# Altium schematic sheet CPLD-V MAIN.SchDoc
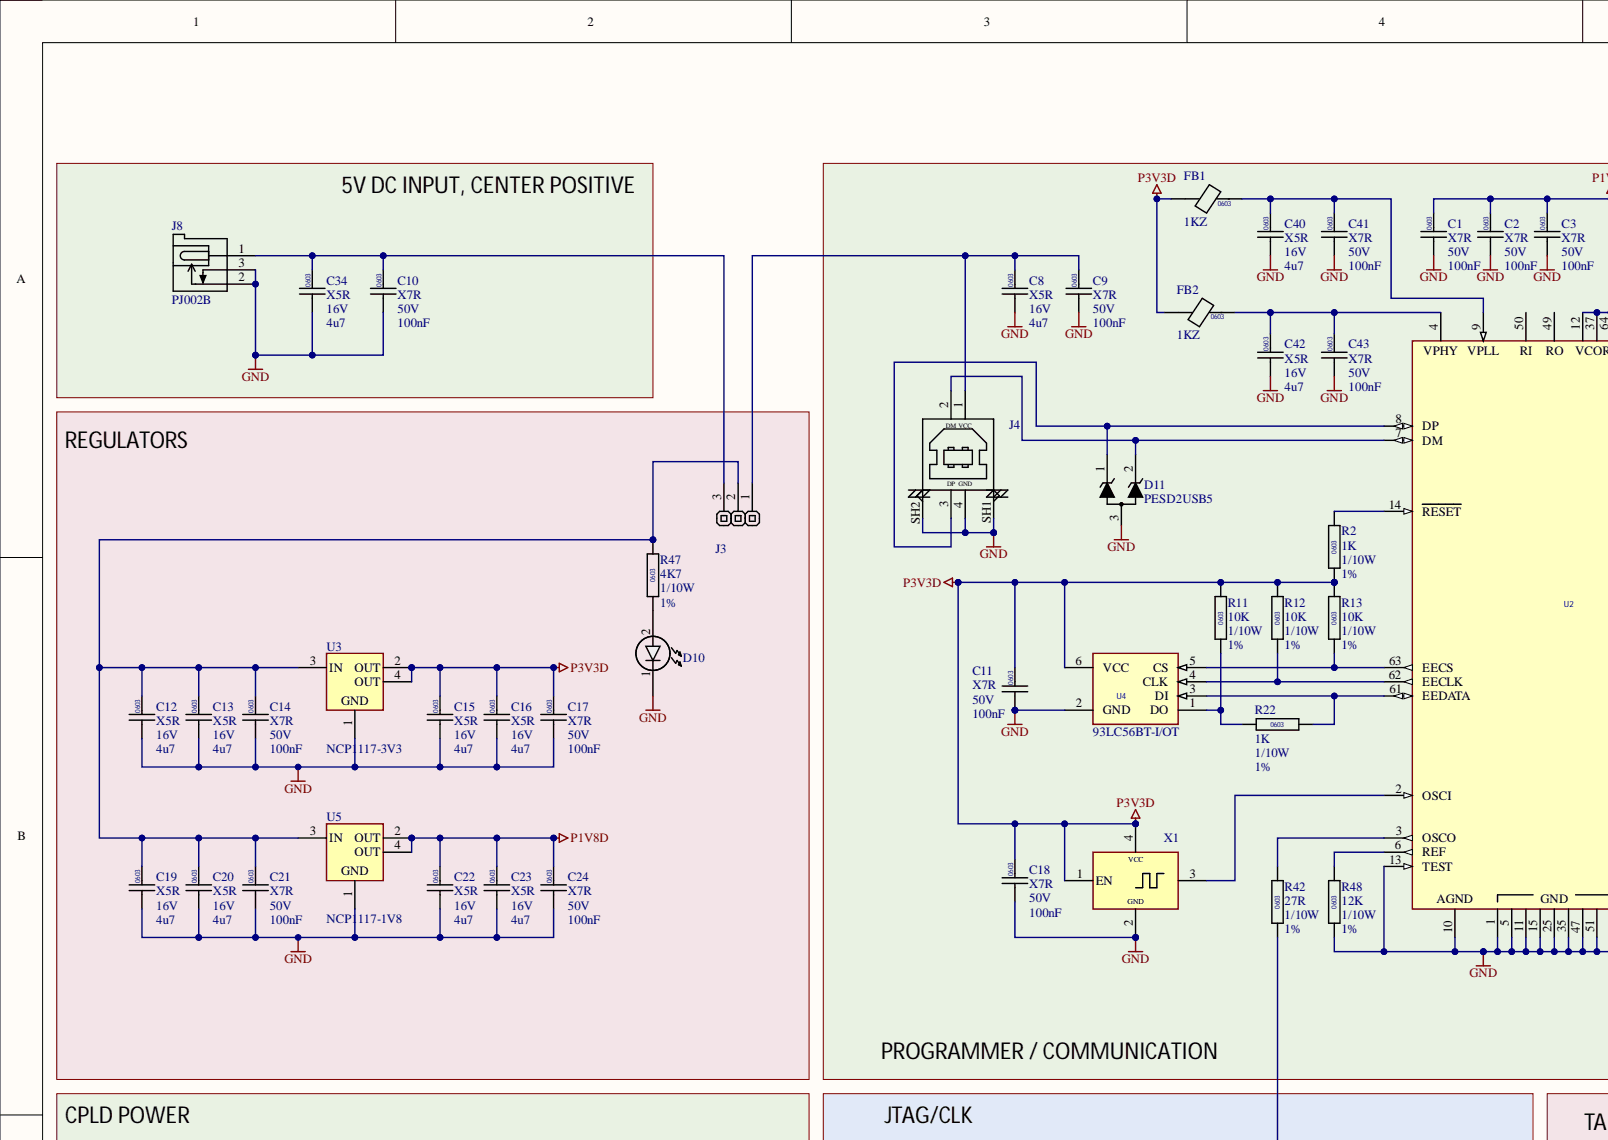
[diagram p0: CPLD-V MAIN.SchDoc, top left]
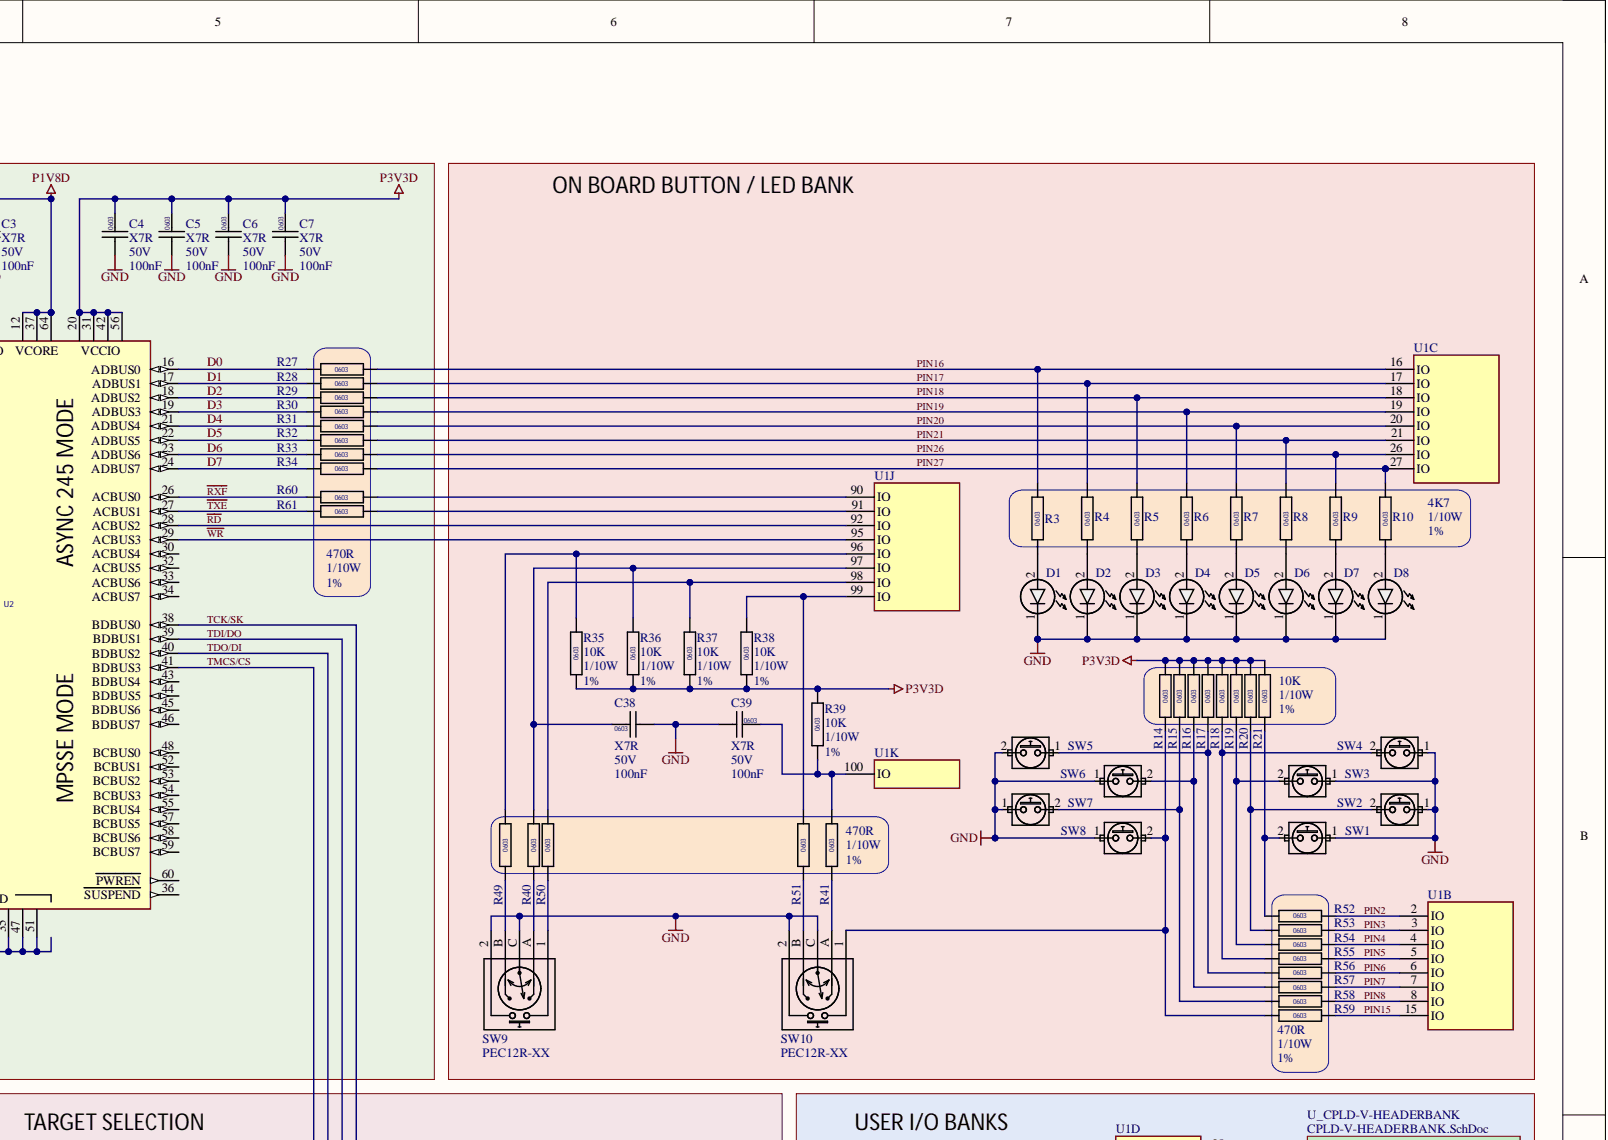
[diagram p1: CPLD-V MAIN.SchDoc, top right]
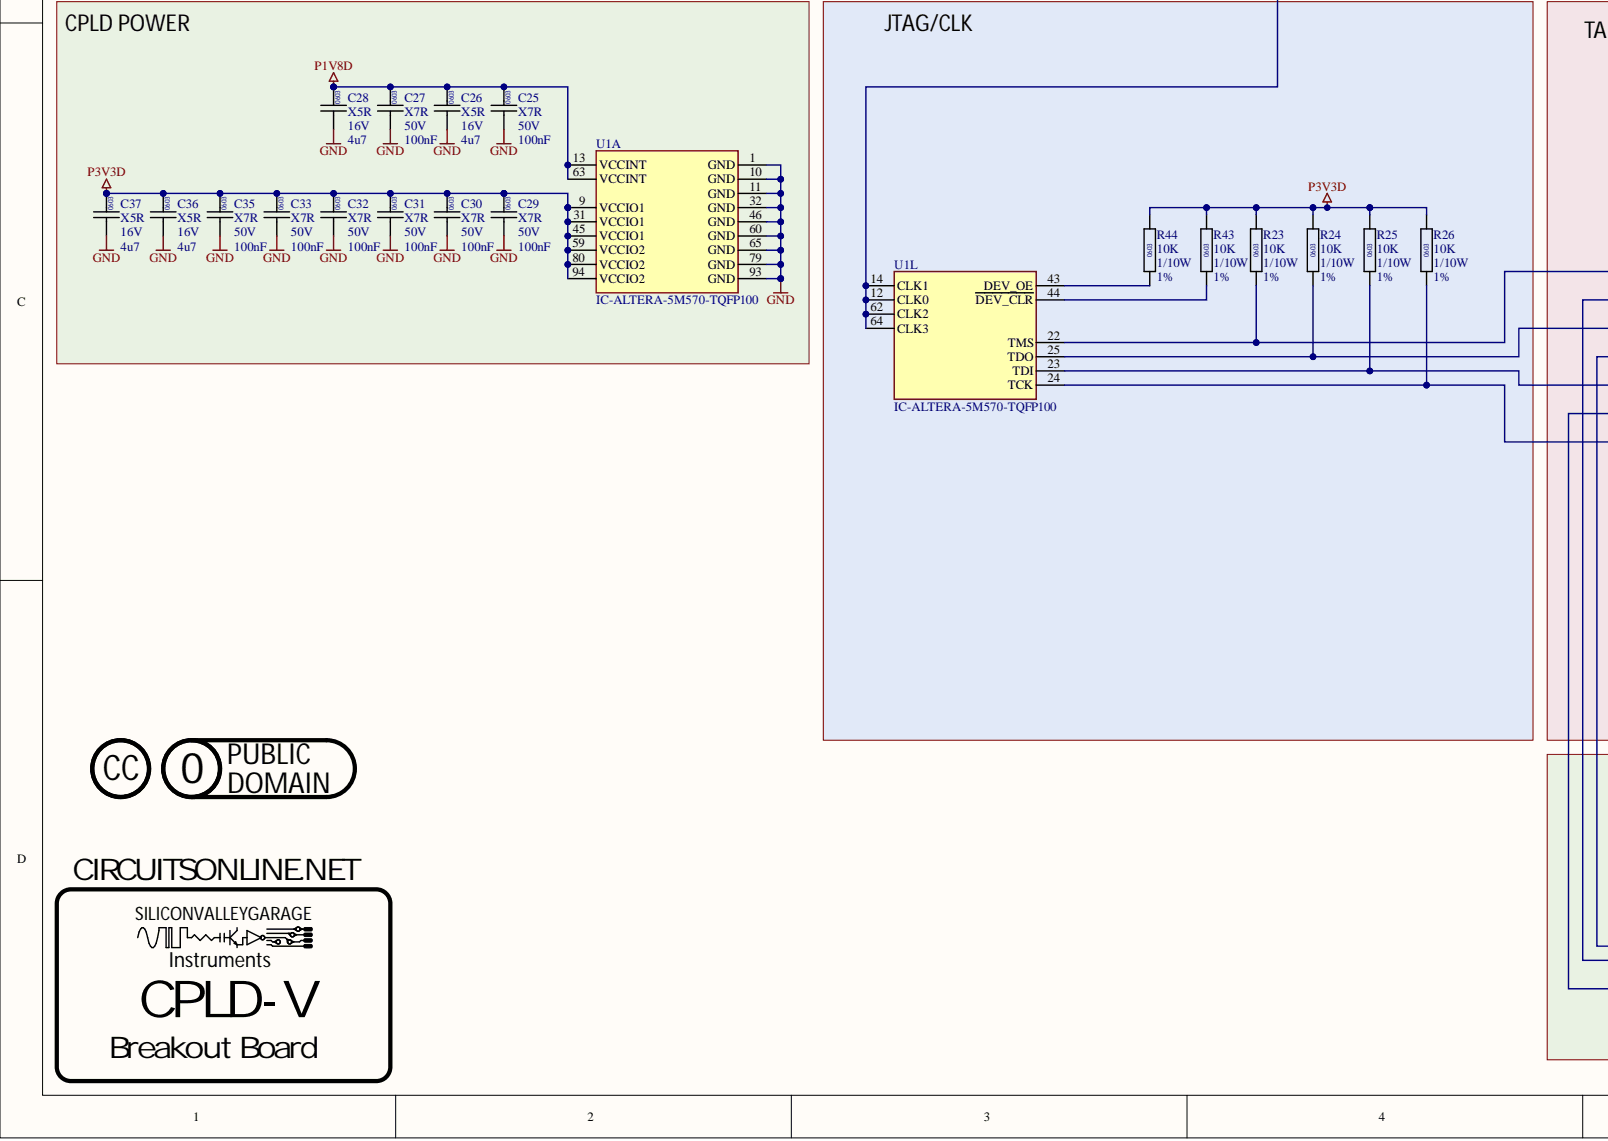
[diagram p2: CPLD-V MAIN.SchDoc, bottom left]
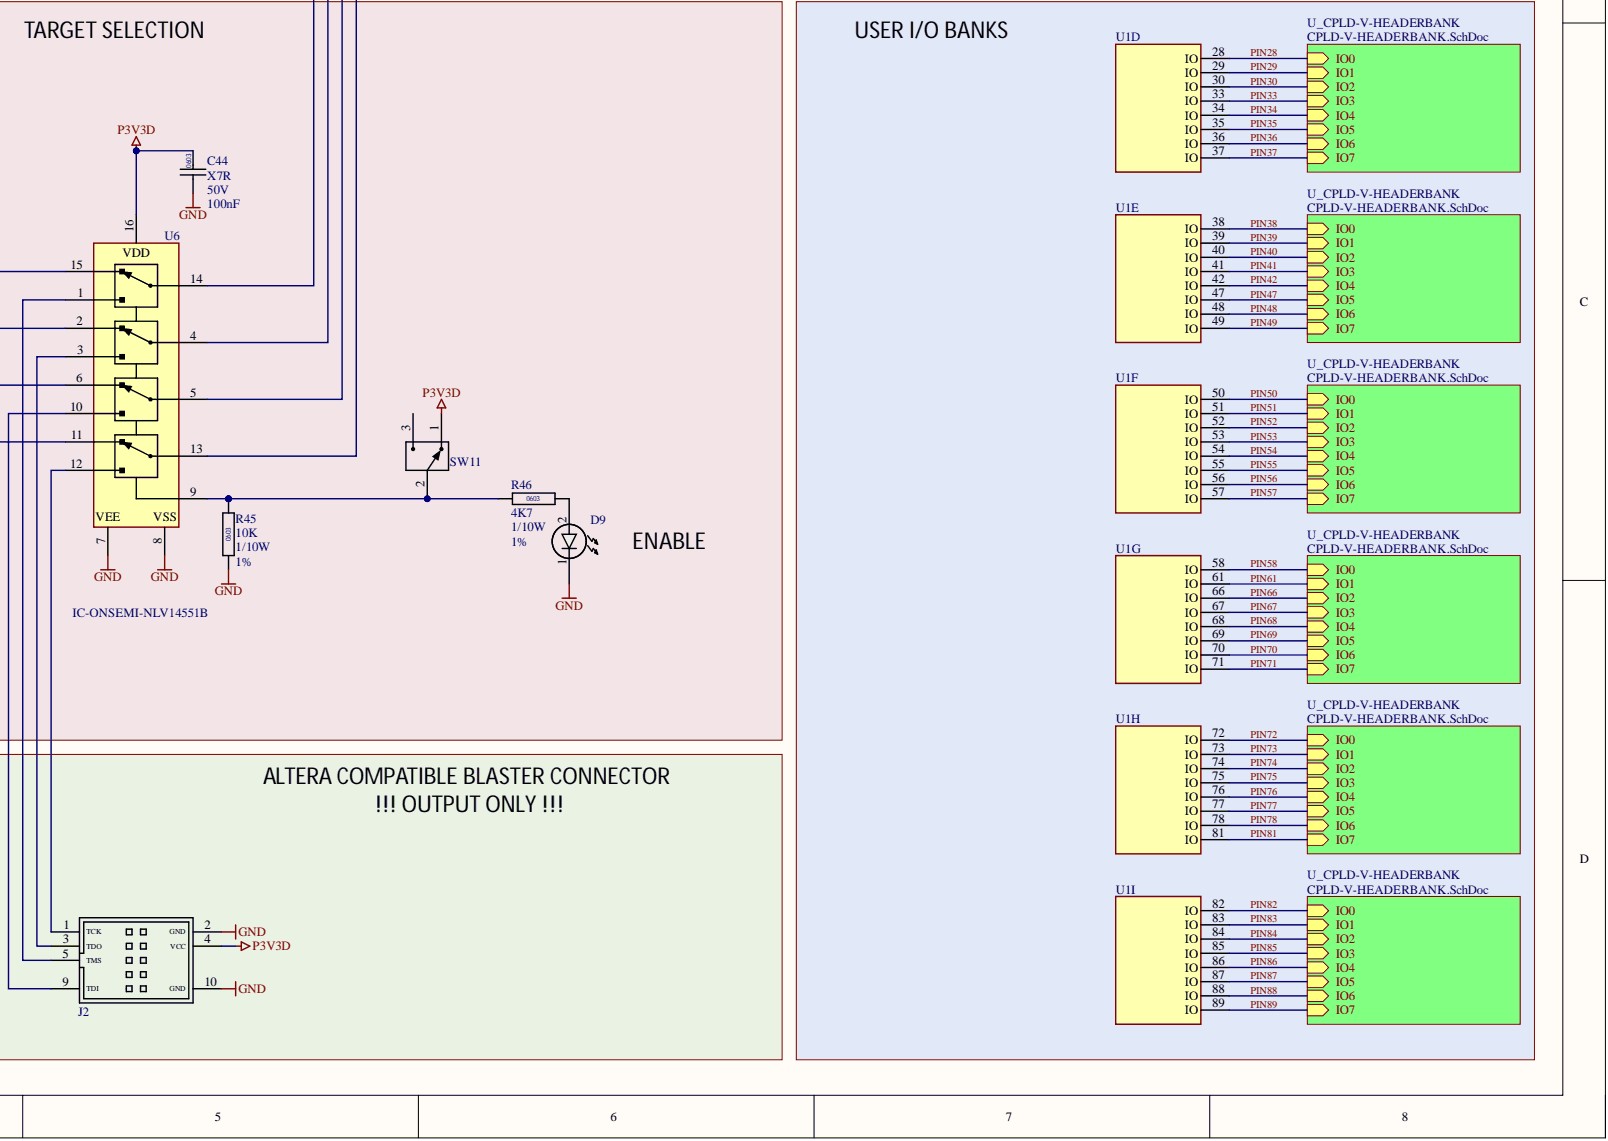
[diagram p3: CPLD-V MAIN.SchDoc, bottom right]

## Parts
C1  Capacitance: 100nF  Dielectric: X7R  Package / Case: 0603  Voltage: 50V  [CAPC0603]  (hidden comment: CAP0603)
C2  Capacitance: 100nF  Dielectric: X7R  Package / Case: 0603  Voltage: 50V  [CAPC0603]  (hidden comment: CAP0603)
C3  Capacitance: 100nF  Dielectric: X7R  Package / Case: 0603  Voltage: 50V  [CAPC0603]  (hidden comment: CAP0603)
C4  Capacitance: 100nF  Dielectric: X7R  Package / Case: 0603  Voltage: 50V  [CAPC0603]  (hidden comment: CAP0603)
C5  Capacitance: 100nF  Dielectric: X7R  Package / Case: 0603  Voltage: 50V  [CAPC0603]  (hidden comment: CAP0603)
C6  Capacitance: 100nF  Dielectric: X7R  Package / Case: 0603  Voltage: 50V  [CAPC0603]  (hidden comment: CAP0603)
C7  Capacitance: 100nF  Dielectric: X7R  Package / Case: 0603  Voltage: 50V  [CAPC0603]  (hidden comment: CAP0603)
C8  Capacitance: 4u7  Dielectric: X5R  Package / Case: 0603  Voltage: 16V  [CAPC0603]  (hidden comment: CAP0603)
C9  Capacitance: 100nF  Dielectric: X7R  Package / Case: 0603  Voltage: 50V  [CAPC0603]  (hidden comment: CAP0603)
C10  Capacitance: 100nF  Dielectric: X7R  Package / Case: 0603  Voltage: 50V  [CAPC0603]  (hidden comment: CAP0603)
C11  Capacitance: 100nF  Dielectric: X7R  Package / Case: 0603  Voltage: 50V  [CAPC0603]  (hidden comment: CAP0603)
C12  Capacitance: 4u7  Dielectric: X5R  Package / Case: 0603  Voltage: 16V  [CAPC0603]  (hidden comment: CAP0603)
C13  Capacitance: 4u7  Dielectric: X5R  Package / Case: 0603  Voltage: 16V  [CAPC0603]  (hidden comment: CAP0603)
C14  Capacitance: 100nF  Dielectric: X7R  Package / Case: 0603  Voltage: 50V  [CAPC0603]  (hidden comment: CAP0603)
C15  Capacitance: 4u7  Dielectric: X5R  Package / Case: 0603  Voltage: 16V  [CAPC0603]  (hidden comment: CAP0603)
C16  Capacitance: 4u7  Dielectric: X5R  Package / Case: 0603  Voltage: 16V  [CAPC0603]  (hidden comment: CAP0603)
C17  Capacitance: 100nF  Dielectric: X7R  Package / Case: 0603  Voltage: 50V  [CAPC0603]  (hidden comment: CAP0603)
C18  Dielectric: X7R  Package / Case: 0603  Voltage: 50V  [CAPC0603]  (hidden comment: CAP0603)
C19  Capacitance: 4u7  Dielectric: X5R  Package / Case: 0603  Voltage: 16V  [CAPC0603]  (hidden comment: CAP0603)
C20  Capacitance: 4u7  Dielectric: X5R  Package / Case: 0603  Voltage: 16V  [CAPC0603]  (hidden comment: CAP0603)
C21  Capacitance: 100nF  Dielectric: X7R  Package / Case: 0603  Voltage: 50V  [CAPC0603]  (hidden comment: CAP0603)
C22  Capacitance: 4u7  Dielectric: X5R  Package / Case: 0603  Voltage: 16V  [CAPC0603]  (hidden comment: CAP0603)
C23  Capacitance: 4u7  Dielectric: X5R  Package / Case: 0603  Voltage: 16V  [CAPC0603]  (hidden comment: CAP0603)
C24  Capacitance: 100nF  Dielectric: X7R  Package / Case: 0603  Voltage: 50V  [CAPC0603]  (hidden comment: CAP0603)
C25  Capacitance: 100nF  Dielectric: X7R  Package / Case: 0603  Voltage: 50V  [CAPC0603]  (hidden comment: CAP0603)
C26  Capacitance: 4u7  Dielectric: X5R  Package / Case: 0603  Voltage: 16V  [CAPC0603]  (hidden comment: CAP0603)
C27  Capacitance: 100nF  Dielectric: X7R  Package / Case: 0603  Voltage: 50V  [CAPC0603]  (hidden comment: CAP0603)
C28  Capacitance: 4u7  Dielectric: X5R  Package / Case: 0603  Voltage: 16V  [CAPC0603]  (hidden comment: CAP0603)
C29  Capacitance: 100nF  Dielectric: X7R  Package / Case: 0603  Voltage: 50V  [CAPC0603]  (hidden comment: CAP0603)
C30  Capacitance: 100nF  Dielectric: X7R  Package / Case: 0603  Voltage: 50V  [CAPC0603]  (hidden comment: CAP0603)
C31  Capacitance: 100nF  Dielectric: X7R  Package / Case: 0603  Voltage: 50V  [CAPC0603]  (hidden comment: CAP0603)
C32  Capacitance: 100nF  Dielectric: X7R  Package / Case: 0603  Voltage: 50V  [CAPC0603]  (hidden comment: CAP0603)
C33  Capacitance: 100nF  Dielectric: X7R  Package / Case: 0603  Voltage: 50V  [CAPC0603]  (hidden comment: CAP0603)
C34  Capacitance: 4u7  Dielectric: X5R  Package / Case: 0603  Voltage: 16V  [CAPC0603]  (hidden comment: CAP0603)
C35  Capacitance: 100nF  Dielectric: X7R  Package / Case: 0603  Voltage: 50V  [CAPC0603]  (hidden comment: CAP0603)
C36  Capacitance: 4u7  Dielectric: X5R  Package / Case: 0603  Voltage: 16V  [CAPC0603]  (hidden comment: CAP0603)
C37  Capacitance: 4u7  Dielectric: X5R  Package / Case: 0603  Voltage: 16V  [CAPC0603]  (hidden comment: CAP0603)
C38  Capacitance: 100nF  Dielectric: X7R  Package / Case: 0603  Voltage: 50V  [CAPC0603]  (hidden comment: CAP0603)
C39  Capacitance: 100nF  Dielectric: X7R  Package / Case: 0603  Voltage: 50V  [CAPC0603]  (hidden comment: CAP0603)
C40  Capacitance: 4u7  Dielectric: X5R  Package / Case: 0603  Voltage: 16V  [CAPC0603]  (hidden comment: CAP0603)
C41  Capacitance: 100nF  Dielectric: X7R  Package / Case: 0603  Voltage: 50V  [CAPC0603]  (hidden comment: CAP0603)
C42  Capacitance: 4u7  Dielectric: X5R  Package / Case: 0603  Voltage: 16V  [CAPC0603]  (hidden comment: CAP0603)
C43  Capacitance: 100nF  Dielectric: X7R  Package / Case: 0603  Voltage: 50V  [CAPC0603]  (hidden comment: CAP0603)
C44  Capacitance: 100nF  Dielectric: X7R  Package / Case: 0603  Voltage: 50V  [CAPC0603]  (hidden comment: CAP0603)
D1  KT-0603W  [LED-0603-GREEN]  (value not drawn)
D2  KT-0603W  [LED-0603-GREEN]  (value not drawn)
D3  KT-0603W  [LED-0603-GREEN]  (value not drawn)
D4  KT-0603W  [LED-0603-GREEN]  (value not drawn)
D5  KT-0603W  [LED-0603-GREEN]  (value not drawn)
D6  KT-0603W  [LED-0603-GREEN]  (value not drawn)
D7  KT-0603W  [LED-0603-GREEN]  (value not drawn)
D8  KT-0603W  [LED-0603-GREEN]  (value not drawn)
D9  KT-0603W  [LED-0603-GREEN]  (value not drawn)
D10  KT-0603W  [LED-0603-GREEN]  (value not drawn)
D11  PESD2USB5  [SOT23]
FB1  value 1KZ  [FB0603]  (hidden comment: FB0603)
FB2  value 1KZ  [FB0603]  (hidden comment: FB0603)
J2  ALTERA  [CONNECTOR GENERIC-BOXHEADER-2X5]  (value not drawn)
J3  BOXHEADER-2X4  [HEADER-GENERIC-MALE-1X3]  (value not drawn)
J4  CONN-CUI-UJ2-BH-BL1-TH  [CONN-CUI-UJ2-BH-BL1-TH]  (value not drawn)
J8  PJ002B  [CONN-CUI-PJ-002B]
LOGO1  LOGO-LICENSE-CC0-PUBLIC  [LOGO-LICENSE-CC0-PUBLIC]  (value not drawn)  (no BOM)
LOGO2  LOGO-LICENSE-CC  [LOGO-LICENSE-CC]  (value not drawn)  (no BOM)
R2  value 1K  Power: 1/10W  Tolerance: 1%  [RESC0603]  (hidden comment: RESC0603)
R3  RESC0603  [RESC0603]  (value not drawn)
R4  RESC0603  [RESC0603]  (value not drawn)
R5  RESC0603  [RESC0603]  (value not drawn)
R6  RESC0603  [RESC0603]  (value not drawn)
R7  RESC0603  [RESC0603]  (value not drawn)
R8  RESC0603  [RESC0603]  (value not drawn)
R9  RESC0603  [RESC0603]  (value not drawn)
R10  value 4K7  Power: 1/10W  Tolerance: 1%  [RESC0603]  (hidden comment: RESC0603)
R11  value 10K  Power: 1/10W  Tolerance: 1%  [RESC0603]  (hidden comment: RESC0603)
R12  value 10K  Power: 1/10W  Tolerance: 1%  [RESC0603]  (hidden comment: RESC0603)
R13  value 10K  Power: 1/10W  Tolerance: 1%  [RESC0603]  (hidden comment: RESC0603)
R14  RESC0603  [RESC0603]  (value not drawn)
R15  RESC0603  [RESC0603]  (value not drawn)
R16  RESC0603  [RESC0603]  (value not drawn)
R17  RESC0603  [RESC0603]  (value not drawn)
R18  RESC0603  [RESC0603]  (value not drawn)
R19  RESC0603  [RESC0603]  (value not drawn)
R20  RESC0603  [RESC0603]  (value not drawn)
R21  value 10K  Power: 1/10W  Tolerance: 1%  [RESC0603]  (hidden comment: RESC0603)
R22  value 1K  Power: 1/10W  Tolerance: 1%  [RESC0603]  (hidden comment: RESC0603)
R23  value 10K  Power: 1/10W  Tolerance: 1%  [RESC0603]  (hidden comment: RESC0603)
R24  value 10K  Power: 1/10W  Tolerance: 1%  [RESC0603]  (hidden comment: RESC0603)
R25  value 10K  Power: 1/10W  Tolerance: 1%  [RESC0603]  (hidden comment: RESC0603)
R26  value 10K  Power: 1/10W  Tolerance: 1%  [RESC0603]  (hidden comment: RESC0603)
R27  RESC0603  [RESC0603]  (value not drawn)
R28  RESC0603  [RESC0603]  (value not drawn)
R29  RESC0603  [RESC0603]  (value not drawn)
R30  RESC0603  [RESC0603]  (value not drawn)
R31  RESC0603  [RESC0603]  (value not drawn)
R32  RESC0603  [RESC0603]  (value not drawn)
R33  RESC0603  [RESC0603]  (value not drawn)
R34  RESC0603  [RESC0603]  (value not drawn)
R35  value 10K  Power: 1/10W  Tolerance: 1%  [RESC0603]  (hidden comment: RESC0603)
R36  value 10K  Power: 1/10W  Tolerance: 1%  [RESC0603]  (hidden comment: RESC0603)
R37  value 10K  Power: 1/10W  Tolerance: 1%  [RESC0603]  (hidden comment: RESC0603)
R38  value 10K  Power: 1/10W  Tolerance: 1%  [RESC0603]  (hidden comment: RESC0603)
R39  value 10K  Power: 1/10W  Tolerance: 1%  [RESC0603]  (hidden comment: RESC0603)
R40  RESC0603  [RESC0603]  (value not drawn)
R41  value 470R  Power: 1/10W  Tolerance: 1%  [RESC0603]  (hidden comment: RESC0603)
R42  value 27R  Power: 1/10W  Tolerance: 1%  [RESC0603]  (hidden comment: RESC0603)
R43  value 10K  Power: 1/10W  Tolerance: 1%  [RESC0603]  (hidden comment: RESC0603)
R44  value 10K  Power: 1/10W  Tolerance: 1%  [RESC0603]  (hidden comment: RESC0603)
R45  value 10K  Power: 1/10W  Tolerance: 1%  [RESC0603]  (hidden comment: RESC0603)
R46  value 4K7  [RESC0603]  (hidden comment: RESC0603)
R47  value 4K7  Power: 1/10W  Tolerance: 1%  [RESC0603]  (hidden comment: RESC0603)
R48  value 12K  Power: 1/10W  Tolerance: 1%  [RESC0603]  (hidden comment: RESC0603)
R49  RESC0603  [RESC0603]  (value not drawn)
R50  RESC0603  [RESC0603]  (value not drawn)
R51  RESC0603  [RESC0603]  (value not drawn)
R52  RESC0603  [RESC0603]  (value not drawn)
R53  RESC0603  [RESC0603]  (value not drawn)
R54  RESC0603  [RESC0603]  (value not drawn)
R55  RESC0603  [RESC0603]  (value not drawn)
R56  RESC0603  [RESC0603]  (value not drawn)
R57  RESC0603  [RESC0603]  (value not drawn)
R58  RESC0603  [RESC0603]  (value not drawn)
R59  value 470R  Power: 1/10W  Tolerance: 1%  [RESC0603]  (hidden comment: RESC0603)
R60  RESC0603  [RESC0603]  (value not drawn)
R61  value 470R  Power: 1/10W  [RESC0603]  (hidden comment: RESC0603)
SW1  SWITCH-XUNPU-TS-1088-AR02016  [SWITCH-XUNPU-TS-1088-AR02016]  (value not drawn)
SW2  SWITCH-XUNPU-TS-1088-AR02016  [SWITCH-XUNPU-TS-1088-AR02016]  (value not drawn)
SW3  SWITCH-XUNPU-TS-1088-AR02016  [SWITCH-XUNPU-TS-1088-AR02016]  (value not drawn)
SW4  SWITCH-XUNPU-TS-1088-AR02016  [SWITCH-XUNPU-TS-1088-AR02016]  (value not drawn)
SW5  SWITCH-XUNPU-TS-1088-AR02016  [SWITCH-XUNPU-TS-1088-AR02016]  (value not drawn)
SW6  SWITCH-XUNPU-TS-1088-AR02016  [SWITCH-XUNPU-TS-1088-AR02016]  (value not drawn)
SW7  SWITCH-XUNPU-TS-1088-AR02016  [SWITCH-XUNPU-TS-1088-AR02016]  (value not drawn)
SW8  SWITCH-XUNPU-TS-1088-AR02016  [SWITCH-XUNPU-TS-1088-AR02016]  (value not drawn)
SW9  PEC12R-XX  [SWITCH-BOURNS-PEC12R-4120F-S0012]
SW10  PEC12R-XX  [SWITCH-BOURNS-PEC12R-4120F-S0012]
SW11  SWITCH-WURTH-450301014042  [SWITCH-WURTH-450301014042]  (value not drawn)
U1  IC-ALTERA-5M570-TQFP100  [QFP50P1600X1600X160-100N]  (parts A,B,C,D,E,F,G,H,I,J,K,L)
U2  FT2232H  [QFP50P1000X1000X100-64N]  (value not drawn)
U3  NCP1117-3V3  [SOT223-4N]
U4  93LC56BT-I/OT  [SOT23-6]
U5  NCP1117-1V8  [SOT223-4N]
U6  IC-ONSEMI-NLV14551B  [SO127P600-16N]
X1  XOSC  [XTAL-ABRACON-ABM8-272-T3]  (value not drawn)

## Nets
GND: C1.2 C2.2 C3.2 C4.2 C5.2 C6.2 C7.2 C8.2 C9.2 C10.2 C11.2 C12.2 C13.2 C14.2 C15.2 C16.2 C17.2 C18.2 C19.2 C20.2 C21.2 C22.2 C23.2 C24.2 C25.2 C26.2 C27.2 C28.2 C29.2 C30.2 C31.2 C32.2 C33.2 C34.2 C35.2 C36.2 C37.2 C38.2 C39.2 C40.2 C41.2 C42.2 C43.2 C44.2 D1.1 D2.1 D3.1 D4.1 D5.1 D6.1 D7.1 D8.1 D9.1 D10.1 D11.3 J2.2(GND) J2.10(GND) J4.4 J4.SH1 J4.SH2 J8.2 J8.3 R45.2 R48.2 SW1.1 SW2.1 SW3.1 SW4.1 SW5.2 SW6.1 SW7.1 SW8.1 SW9.2 SW9.C SW10.2 SW10.C U1.1(GND) U1.10(GND) U1.11(GND) U1.32(GND) U1.46(GND) U1.60(GND) U1.65(GND) U1.79(GND) U1.93(GND) U2.1 U2.5 U2.10 U2.11 U2.13(TEST) U2.15 U2.25 U2.35 U2.47 U2.51 U3.1 U4.2(GND) U5.1 U6.7 U6.8 X1.2
P1V8D: C1.1 C2.1 C3.1 C22.1 C23.1 C24.1 C25.1 C26.1 C27.1 C28.1 U1.13(VCCINT) U1.63(VCCINT) U2.12 U2.37 U2.64 U5.2(OUT) U5.4(OUT)
P3V3D: C4.1 C5.1 C6.1 C7.1 C11.1 C15.1 C16.1 C17.1 C18.1 C29.1 C30.1 C31.1 C32.1 C33.1 C35.1 C36.1 C37.1 C44.1 FB1.2 FB2.2 J2.4(VCC) R2.1 R11.1 R12.1 R13.1 R14.1 R15.1 R16.1 R17.1 R18.1 R19.1 R20.1 R21.1 R23.1 R24.1 R25.1 R26.1 R35.1 R36.1 R37.1 R38.1 R39.1 R43.2 R44.2 SW11.1 U1.9(VCCIO1) U1.31(VCCIO1) U1.45(VCCIO1) U1.59(VCCIO2) U1.80(VCCIO2) U1.94(VCCIO2) U2.20 U2.31 U2.42 U2.56 U3.2(OUT) U3.4(OUT) U4.6(VCC) U6.16 X1.1(EN) X1.4
D0: R27.1 U2.16(ADBUS0)
D1: R28.1 U2.17(ADBUS1)
D2: R29.1 U2.18(ADBUS2)
D3: R30.1 U2.19(ADBUS3)
D4: R31.1 U2.21(ADBUS4)
D5: R32.1 U2.22(ADBUS5)
D6: R33.1 U2.23(ADBUS6)
D7: R34.1 U2.24(ADBUS7)
PIN2: R52.2 U1.2(IO)
PIN3: R53.2 U1.3(IO)
PIN4: R54.2 U1.4(IO)
PIN5: R55.2 U1.5(IO)
PIN6: R56.2 U1.6(IO)
PIN7: R57.2 U1.7(IO)
PIN8: R58.2 U1.8(IO)
PIN15: R59.2 U1.15(IO)
PIN16: R3.2 R27.2 U1.16(IO)
PIN17: R4.2 R28.2 U1.17(IO)
PIN18: R5.2 R29.2 U1.18(IO)
PIN19: R6.2 R30.2 U1.19(IO)
PIN20: R7.2 R31.2 U1.20(IO)
PIN21: R8.2 R32.2 U1.21(IO)
PIN26: R9.2 R33.2 U1.26(IO)
PIN27: R10.2 R34.2 U1.27(IO)
PIN28: U1.28(IO)
PIN29: U1.29(IO)
PIN30: U1.30(IO)
PIN33: U1.33(IO)
PIN34: U1.34(IO)
PIN35: U1.35(IO)
PIN36: U1.36(IO)
PIN37: U1.37(IO)
PIN38: U1.38(IO)
PIN39: U1.39(IO)
PIN40: U1.40(IO)
PIN41: U1.41(IO)
PIN42: U1.42(IO)
PIN47: U1.47(IO)
PIN48: U1.48(IO)
PIN49: U1.49(IO)
PIN50: U1.50(IO)
PIN51: U1.51(IO)
PIN52: U1.52(IO)
PIN53: U1.53(IO)
PIN54: U1.54(IO)
PIN55: U1.55(IO)
PIN56: U1.56(IO)
PIN57: U1.57(IO)
PIN58: U1.58(IO)
PIN61: U1.61(IO)
PIN66: U1.66(IO)
PIN67: U1.67(IO)
PIN68: U1.68(IO)
PIN69: U1.69(IO)
PIN70: U1.70(IO)
PIN71: U1.71(IO)
PIN72: U1.72(IO)
PIN73: U1.73(IO)
PIN74: U1.74(IO)
PIN75: U1.75(IO)
PIN76: U1.76(IO)
PIN77: U1.77(IO)
PIN78: U1.78(IO)
PIN81: U1.81(IO)
PIN82: U1.82(IO)
PIN83: U1.83(IO)
PIN84: U1.84(IO)
PIN85: U1.85(IO)
PIN86: U1.86(IO)
PIN87: U1.87(IO)
PIN88: U1.88(IO)
PIN89: U1.89(IO)
~{RD}: U1.92(IO) U2.28(ACBUS2)
~{RXF}: R60.1 U2.26(ACBUS0)
~{TXE}: R61.1 U2.27(ACBUS1)
TCK/SK: U2.38(BDBUS0) U6.13
TDI/DO: U2.39(BDBUS1) U6.5
TDO/DI: U2.40(BDBUS2) U6.4
TMCS/CS: U2.41(BDBUS3) U6.14
~{WR}: U1.95(IO) U2.29(ACBUS3)
NetC8_1: C8.1 C9.1 J3.1 J4.1
NetC10_1: C10.1 C34.1 J3.3 J8.1
NetC12_1: C12.1 C13.1 C14.1 C19.1 C20.1 C21.1 J3.2 R47.2 U3.3(IN) U5.3(IN)
NetC38_1: C38.1 R36.2 R40.2 U1.97(IO)
NetC39_1: C39.1 R39.2 R41.2 U1.100(IO)
NetC40_1: C40.1 C41.1 FB1.1 U2.9
NetC42_1: C42.1 C43.1 FB2.1 U2.4
NetD1_2: D1.2 R3.1
NetD2_2: D2.2 R4.1
NetD3_2: D3.2 R5.1
NetD4_2: D4.2 R6.1
NetD5_2: D5.2 R7.1
NetD6_2: D6.2 R8.1
NetD7_2: D7.2 R9.1
NetD8_2: D8.2 R10.1
NetD9_2: D9.2 R46.1
NetD10_2: D10.2 R47.1
NetD11_1: D11.1 J4.3(DP) U2.8(DP)
NetD11_2: D11.2 J4.2(DM) U2.7(DM)
NetJ2_1: J2.1(TCK) U6.12
NetJ2_3: J2.3(TDO) U6.3
NetJ2_5: J2.5(TMS) U6.1
NetJ2_9: J2.9(TDI) U6.10
NetR2_2: R2.2 U2.14(RESET)
NetR11_2: R11.2 R22.1 U4.1(DO)
NetR12_2: R12.2 U2.62(EECLK) U4.4(CLK)
NetR13_2: R13.2 U2.63(EECS) U4.5(CS)
NetR14_2: R14.2 R59.1 SW8.2 SW10.1
NetR15_2: R15.2 R58.1 SW7.2
NetR16_2: R16.2 R57.1 SW6.2
NetR17_2: R17.2 R56.1 SW5.1
NetR18_2: R18.2 R55.1 SW4.2
NetR19_2: R19.2 R54.1 SW3.2
NetR20_2: R20.2 R53.1 SW2.2
NetR21_2: R21.2 R52.1 SW1.2
NetR22_2: R22.2 U2.61(EEDATA) U4.3(DI)
NetR23_2: R23.2 U1.22(TMS) U6.15
NetR24_2: R24.2 U1.25(TDO) U6.2
NetR25_2: R25.2 U1.23(TDI) U6.6
NetR26_2: R26.2 U1.24(TCK) U6.11
NetR35_2: R35.2 R49.2 U1.96(IO)
NetR37_2: R37.2 R50.2 U1.98(IO)
NetR38_2: R38.2 R51.2 U1.99(IO)
NetR40_1: R40.1 SW9.A
NetR41_1: R41.1 SW10.A
NetR42_1: R42.1 U2.3(OSCO)
NetR42_2: R42.2 U1.12(CLK0) U1.14(CLK1) U1.62(CLK2) U1.64(CLK3)
NetR43_1: R43.1 U1.44(DEV_CLR)
NetR44_1: R44.1 U1.43(DEV_OE)
NetR45_1: R45.1 R46.2 SW11.2 U6.9
NetR48_1: R48.1 U2.6(REF)
NetR49_1: R49.1 SW9.B
NetR50_1: R50.1 SW9.1
NetR51_1: R51.1 SW10.B
NetR60_2: R60.2 U1.90(IO)
NetR61_2: R61.2 U1.91(IO)
NetSW11_3: SW11.3
NetU2_2: U2.2(OSCI) X1.3
NetU2_30: U2.30(ACBUS4)
NetU2_32: U2.32(ACBUS5)
NetU2_33: U2.33(ACBUS6)
NetU2_34: U2.34(ACBUS7)
NetU2_36: U2.36(SUSPEND)
NetU2_43: U2.43(BDBUS4)
NetU2_44: U2.44(BDBUS5)
NetU2_45: U2.45(BDBUS6)
NetU2_46: U2.46(BDBUS7)
NetU2_48: U2.48(BCBUS0)
NetU2_49: U2.49(RO)
NetU2_50: U2.50(RI)
NetU2_52: U2.52(BCBUS1)
NetU2_53: U2.53(BCBUS2)
NetU2_54: U2.54(BCBUS3)
NetU2_55: U2.55(BCBUS4)
NetU2_57: U2.57(BCBUS5)
NetU2_58: U2.58(BCBUS6)
NetU2_59: U2.59(BCBUS7)
NetU2_60: U2.60(PWREN)

## Library data of the parts
C1-C7 C9-C11 C14 C17 C18 C21 C24 C25 C27 C29-C33 C35 C38 C39 C41 C43 C44 = CAPC-0603-100nF-50V-X7R: CAPACITOR,CERAMIC,100nF,50V,X7R,0603; Manufacturer 1: KEMET; Manufacturer Part Number 1: C0603C104K5RACTU; Component Kind: Standard; LCSC: C14663; Library Name: CAPACITORS.SchLib; MOUSER: 80-C0603C104K5R
  C18: Capacitance: 100nF
C8 C12 C13 C15 C16 C19 C20 C22 C23 C26 C28 C34 C36 C37 C40 C42 = CAPC-0603-4u7-10V-X5R_1: CAPACITOR,CERAMIC,4u7,16V,X5R,0603; Manufacturer 1: Samsung Electro-Mechanics; Manufacturer Part Number 1: CL10A475KO8NNNC; Component Kind: Standard; LCSC: C19666; Library Name: CAPACITORS.SchLib
D1-D10 = LED-0603-WHITE: LED,SMD,WHITE,42mCd,0603; Manufacturer 1: Hubei KENTO Elec; Component Kind: Standard; LCSC: C2290; Library Name: LED.SchLib; Manufacturer Part Number 1: KT-0603W
D11 = TVS-NEXPERIA-PESD2USB5UX-TR: DIODE,TVS,DUAL,NIDIRETIONAL,USB,PESD2USB5UX-TR,SOT23; Component Kind: Standard; DIGIKEY: 1727-PESD2USB5UX-CT-ND; LCSC: C3709087; Library Name: DIODES.SchLib; Manufacturer 1: NEXPERIA; Manufacturer Part Number 1: PESD2USB5UX-TR
FB1 FB2 = FB0603-1KZ-0R2: FERRITE,1KZ@100MHz,0R2DC,0603; Manufacturer 1: MURATA; Manufacturer Part Number 1: BLM18KG102SN1D; Component Kind: Standard; LCSC: C160982; MOUSER: 81-BLM18KG102SN1D; Supplier 1: Arrow Electronics; Supplier Part Number 1: BLM18KG102SN1D; Library Name: FERRITES.SCHLIB
J2 = CONN-BOXHEADER-5X2-ALTERA: CONN,BOXHEADER,2X5,ALTERA JTAG PINOUT; Component Kind: Standard; Library Name: CONNECTORS.SchLib
J3 = CONN-HEADER-MALE-1x3: CONN,HEADER,1x3,2.54mm; Component Kind: Standard; LCSC: C429954; Library Name: CONNECTORS.SchLib
J4 = CONN-CUI-UJ2-BH-BL1-TH: CONN,USB2.0,B,RA,BLUE; Component Kind: Standard; LCSC: C720549; Library Name: CONNECTORS.SchLib
J8 = CONN-CUI-PJ002B: CONN,DCPOWER,BARREL,CUI,PJ002B; Manufacturer 1: XKB Connection; Manufacturer Part Number 1: DC-005-5A-2.5; Component Kind: Standard; LCSC: C381115; Library Name: CONNECTORS.SchLib
R2 R22 = RESC-0603-1K-1%-1/10W: RESISTOR,1K,1%,100mW,0603 (1608); Manufacturer 1: YAGEO; Manufacturer Part Number 1: RC0603FR-071KL; Component Kind: Standard; LCSC: C21190; Library Name: RESISTORS.SchLib; MOUSER: 603-RC0603FR-071KL
R3-R9 R46 = RESC-0603-4K7-1%-1/10W: RESISTOR,4K7,1%,100mW,0603 (1608); Manufacturer 1: YAGEO; Manufacturer Part Number 1: RC0603FR-074K7L; Power: 1/10W; Component Kind: Standard; LCSC: C23162; Library Name: RESISTORS.SchLib; MOUSER: 603-RC0603FR-074K7L; Tolerance: 1%
  R3-R9: Value: 4K7
R10 R47 = RESC-0603-4K7-1%-1/10W: RESISTOR,4K7,1%,100mW,0603 (1608); Manufacturer 1: YAGEO; Manufacturer Part Number 1: RC0603FR-074K7L; Component Kind: Standard; LCSC: C23162; Library Name: RESISTORS.SchLib; MOUSER: 603-RC0603FR-074K7L
R11-R13 R21 R23-R26 R35-R39 R43-R45 = RESC-0603-10K-1%-1/10W: RESISTOR,10K,1%,100mW,0603 (1608); Manufacturer 1: YAGEO; Manufacturer Part Number 1: RC0603FR-0710KL; Component Kind: Standard; LCSC: C25804; Library Name: RESISTORS.SchLib; MOUSER: 603-RC0603FR-0710KL
R14-R20 = RESC-0603-10K-1%-1/10W: RESISTOR,10K,1%,100mW,0603 (1608); Manufacturer 1: YAGEO; Manufacturer Part Number 1: RC0603FR-0710KL; Power: 1/10W; Component Kind: Standard; LCSC: C25804; Library Name: RESISTORS.SchLib; MOUSER: 603-RC0603FR-0710KL; Tolerance: 1%; Value: 10K
R27-R34 R40 R49-R58 R60 = RESC-0603-470R-1%-1/10W: RESISTOR,470R,1%,100mW,0603 (1608); Manufacturer 1: UNI-ROYAL; Manufacturer Part Number 1: 0603WAF4700T5E; Power: 1/10W; Component Kind: Standard; LCSC: C23179; Library Name: RESISTORS.SchLib; Tolerance: 1%; Value: 470R
R41 R59 R61 = RESC-0603-470R-1%-1/10W: RESISTOR,470R,1%,100mW,0603 (1608); Manufacturer 1: UNI-ROYAL; Manufacturer Part Number 1: 0603WAF4700T5E; Component Kind: Standard; LCSC: C23179; Library Name: RESISTORS.SchLib
  R61: Tolerance: 1%
R42 = RESC-0603-27R-1%-1/10W_1: RESISTOR,27R,1%,100mW,0603 (1608); Manufacturer 1: YAGEO; Manufacturer Part Number 1: RC0603FR-0727RL; Component Kind: Standard; LCSC: C137753; Library Name: RESISTORS.SchLib
R48 = RESC-0603-12K-1%-1/10W: RESISTOR,12K,1%,100mW,0603 (1608); Manufacturer 1: STACKPOLE; Manufacturer Part Number 1: RMCF0603FT12K0; Component Kind: Standard; LCSC: C22790; Library Name: RESISTORS.SchLib; MOUSER: 708-RMCF0603FT12K0
SW1-SW8 = SWITCH-XUNPU-TS-1088-AR02016: SWITCH,TACT,SMD,2PIN,SMT,TOP ACTUATOR; Manufacturer 1: XUNPU; Component Kind: Standard; LCSC: C720477; Library Name: SWITCH.SchLib; Manufacturer Part Number 1: TS-1088-AR02016
SW9 SW10 = SWITCH-BOURNS-PEC12R-4130F-S0012: SWITCH,ENCODER+CLICK,12 DETENTS,30mm,BOURNS,PEC12R-4130F-S0012; Component Kind: Standard; LCSC: C143795; Library Name: SWITCH.SchLib; Manufacturer 1: BOURNS; Manufacturer Part Number 1: PEC12R-4130F-S0012
SW11 = SWITCH-WURTH-450301014042: SWITCH,SPDT,SLIDE,2.45MM,WURTH-450301014042; Manufacturer 1: SM SWITCH; Manufacturer Part Number 1: BSI-10; Component Kind: Standard; LCSC: C2985934; Library Name: SWITCH.SchLib
U1 = IC-ALTERA-5M570-TQFP100: IC,LOGIC,CPLD,ALTERA,5M570-TQFP100; Manufacturer 1: INTEL/ALTERA; Manufacturer Part Number 1: 5M570ZT100I5N; Component Kind: Standard; LCSC: C1521119; Library Name: IC-MEMORY.SchLib
U2 = IC-FTDI-FT2232H: IC,XCVR,USB UART,DUAL,FTDI,FT2232H,QFP64; Manufacturer Part Number 1: FT2232HL-REEL; Component Kind: Standard; LCSC: C27882; Library Name: IC-XCVR.SchLib; Manufacturer 1: FTDI
U3 = IC-ONSEMI-NCP1117-3V3: IC,VOLTAGE REG,LIN,POSITIVE,3V3,NCP1117-33,SOT223; Manufacturer 1: ONSEMI; Manufacturer Part Number 1: NCP1117ST33T3G; Component Kind: Standard; LCSC: C26537; Library Name: IC-REG.SchLib
U4 = IC-MICROCHIPIC-93LC56-SOT26: IC,EEPROM,2KBIT,MICROWIRE,MICROCHIP,93LC56BT-I/OT; Component Kind: Standard; LCSC: C190271; Library Name: IC-MEMORY.SchLib; Manufacturer 1: MICROCHIP; Manufacturer Part Number 1: 93LC56BT-I/OT
U5 = IC-ONSEMI-NCP1117-1V8: IC,VOLTAGE REG,LIN,POSITIVE,1V8,NCP1117-18,SOT223; Manufacturer 1: ONSEMI; Manufacturer Part Number 1: NCP1117IST18T3G; Component Kind: Standard; LCSC: C2902118; Library Name: IC-REG.SchLib
U6 = IC-ONSEMI-NLV14551B: IC,ANALOG,4PDT ANALOG SWITCH,ONSEMI,NLV14551,SO16; Manufacturer Part Number 1: NLV14551BDR2G; Component Kind: Standard; LCSC: C904812; Library Name: IC-ANALOG.SchLib; Manufacturer 1: ONSEMI
X1 = XOSC-JLYE-Y322512MNCCZ: XOSC,12MHz,3225; Manufacturer 1: JLYE; Component Kind: Standard; LCSC: C49207924; Library Name: XTAL.SchLib; Manufacturer Part Number 1: Y322512MNCCZ
LOGO1 = LOGO-LICENSE-CC0-PUBLIC: Library Name: LOGO.SCHLIB
LOGO2 = LOGO-LICENSE-CC: Library Name: LOGO.SCHLIB
